# Altium schematic sheet main.SchDoc
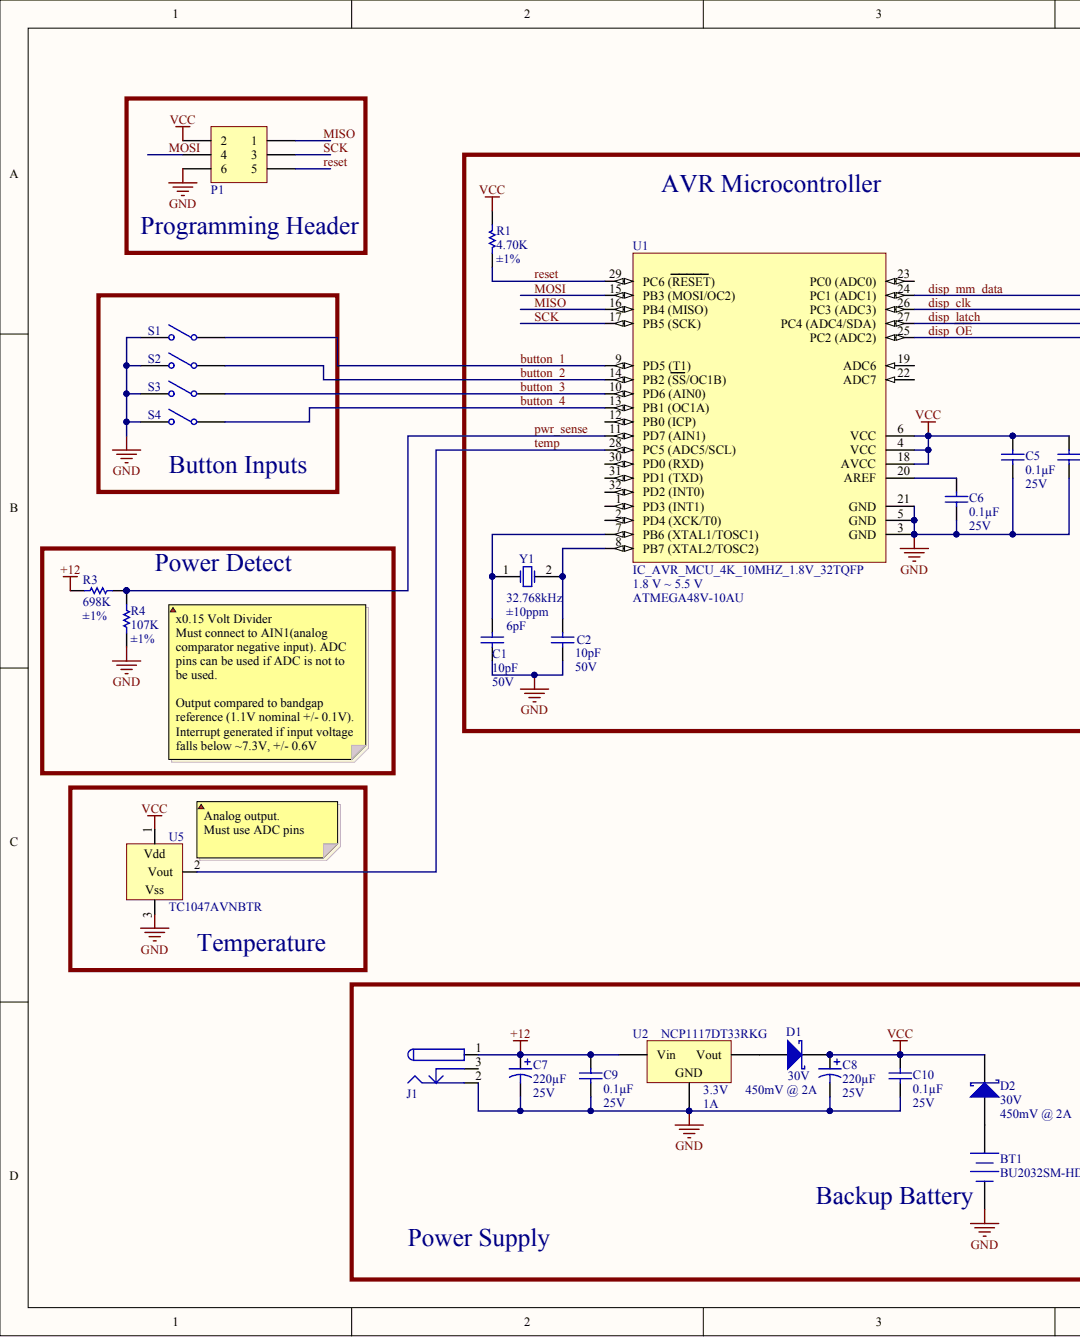
[diagram p0: main.SchDoc, left]
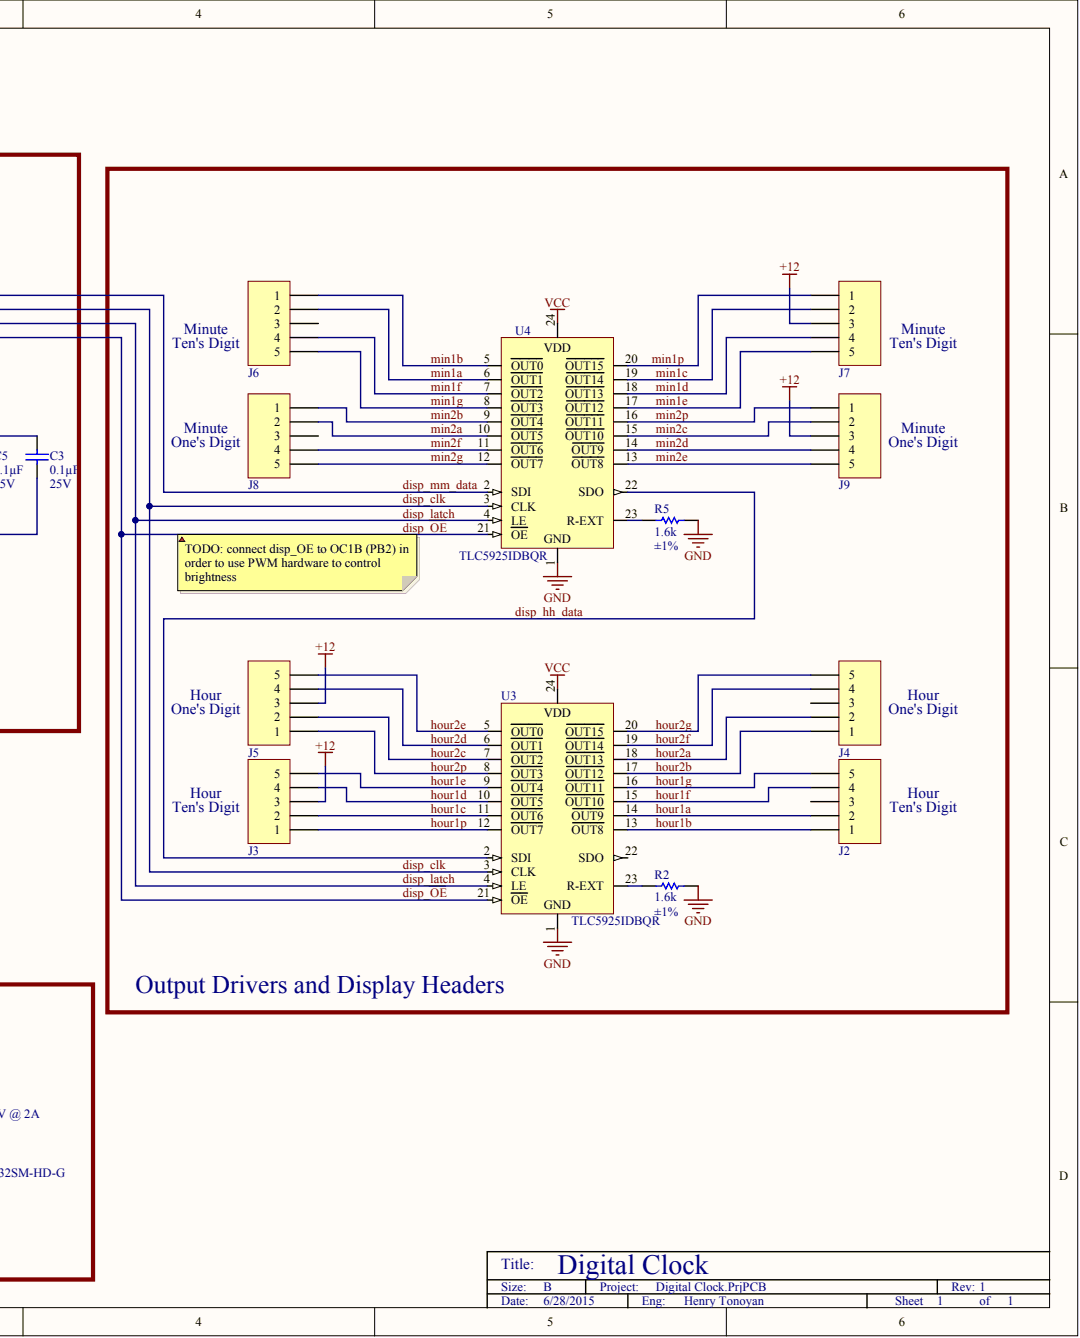
[diagram p1: main.SchDoc, right]

## Parts
BT1  HOLDER COIN CELL 2032 SMD  [BU2032SM-HD-G]  (value not drawn)
C1  Capacitance: 10pF  Voltage - Rated: 50V  [0603]  (hidden comment: CAP CER 10PF 50V 5% C0G 0603)
C2  Capacitance: 10pF  Voltage - Rated: 50V  [0603]  (hidden comment: CAP CER 10PF 50V 5% C0G 0603)
C3  Capacitance: 0.1µF  Voltage - Rated: 25V  [0603]  (hidden comment: CAP CER .10UF 25V X7R 10% 0603)
C5  Capacitance: 0.1µF  Voltage - Rated: 25V  [0603]  (hidden comment: CAP CER .10UF 25V X7R 10% 0603)
C6  Capacitance: 0.1µF  Voltage - Rated: 25V  [0603]  (hidden comment: CAP CER .10UF 25V X7R 10% 0603)
C7  Capacitance: 220µF  Voltage Rating: 25V  [CAP AVE227M25F24T-F]  (hidden comment: CAP ALUM 220UF 25V 20% SMD)
C8  Capacitance: 220µF  Voltage Rating: 25V  [CAP AVE227M25F24T-F]  (hidden comment: CAP ALUM 220UF 25V 20% SMD)
C9  Capacitance: 0.1µF  Voltage - Rated: 25V  [0603]  (hidden comment: CAP CER .10UF 25V X7R 10% 0603)
C10  Capacitance: 0.1µF  Voltage - Rated: 25V  [0603]  (hidden comment: CAP CER .10UF 25V X7R 10% 0603)
D1  Voltage - DC Reverse (Vr) (Max): 30V  [DSO-C2/X2.3]  (hidden comment: DIODE_SCHOTTKY_30V_2A_SMB)
D2  Voltage - DC Reverse (Vr) (Max): 30V  [DSO-C2/X2.3]  (hidden comment: DIODE_SCHOTTKY_30V_2A_SMB)
J1  CONN_PWR2.0_SMT  [CONN_PWR2.0_SMT]  (value not drawn)
J2  CONN_HEADER_VERT_5POS_.100_TIN  [CONN_HDR1X5]  (value not drawn)
J3  CONN_HEADER_VERT_5POS_.100_TIN  [CONN_HDR1X5]  (value not drawn)
J4  CONN_HEADER_VERT_5POS_.100_TIN  [CONN_HDR1X5]  (value not drawn)
J5  CONN_HEADER_VERT_5POS_.100_TIN  [CONN_HDR1X5]  (value not drawn)
J6  CONN_HEADER_VERT_5POS_.100_TIN  [CONN_HDR1X5]  (value not drawn)
J7  CONN_HEADER_VERT_5POS_.100_TIN  [CONN_HDR1X5]  (value not drawn)
J8  CONN_HEADER_VERT_5POS_.100_TIN  [CONN_HDR1X5]  (value not drawn)
J9  CONN_HEADER_VERT_5POS_.100_TIN  [CONN_HDR1X5]  (value not drawn)
P1  Header, 3-Pin, Dual row  [CONN_HDR_SMT_6_2R]  (value not drawn)
R1  Resistance (Ohms): 4.70K  Tolerance: ±1%  [0603]  (hidden comment: RES_4.70K_OHM_1/10W_1%_0603_SMD)
R2  Resistance (Ohms): 1.6k  Tolerance: ±1%  [0603]  (hidden comment: RES_1.60K_OHM_1/10W_1%_0603_SMD)
R3  Resistance (Ohms): 698K  Tolerance: ±1%  [0603]  (hidden comment: RES_698K_OHM_1/10W_1%_0603_SMD)
R4  Resistance (Ohms): 107K  Tolerance: ±1%  [0603]  (hidden comment: RES_107K_OHM_1/10W_1%_0603_SMD)
R5  Resistance (Ohms): 1.6k  Tolerance: ±1%  [0603]  (hidden comment: RES_1.60K_OHM_1/10W_1%_0603_SMD)
S1  SW_1571634-2  [1571634-2]  (value not drawn)
S2  SW_1571634-2  [1571634-2]  (value not drawn)
S3  SW_1571634-2  [1571634-2]  (value not drawn)
S4  SW_1571634-2  [1571634-2]  (value not drawn)
U1  IC_AVR_MCU_4K_10MHZ_1.8V_32TQFP  Manufacturer Part Number: ATMEGA48V-10AU  Voltage - Supply (Vcc/Vdd): 1.8 V ~ 5.5 V  [32A_N]
U2  Current - Limit (Min): 1A  Manufacturer Part Number: NCP1117DT33RKG  Voltage - Output: 3.3V  [DPAK]  (hidden comment: IC_REG_LDO_3.3V_DPAK)
U3  Manufacturer Part Number: TLC5925IDBQR  [SOP24]  (hidden comment: IC_LED_DRIVER_LINEAR_24-QSOP)
U4  Manufacturer Part Number: TLC5925IDBQR  [SOP24]  (hidden comment: IC_LED_DRIVER_LINEAR_24-QSOP)
U5  Manufacturer Part Number: TC1047AVNBTR  [SOT23-3N]  (hidden comment: Temperature Sensor)
Y1  Frequency: 32.768kHz  [ABS25]  (hidden comment: CRYSTAL_32.768_KHZ_6.0 PF_SMD)

## Nets
+12: C7.1 C9.2 J1.1 J3.3 J5.3 J7.3 J9.3 R3.1 U2.1
GND: BT1.2 C1.1 C2.1 C3.2 C5.2 C6.2 C7.2 C8.2 C9.1 C10.1 J1.2 P1.6 R2.2 R4.1 R5.2 S1.2 S2.2 S3.2 S4.2 U1.3(GND) U1.5(GND) U1.21(GND) U2.2 U3.1 U4.1 U5.3
VCC: C3.1 C5.1 C8.1 C10.2 D1.2 D2.2 P1.2 R1.2 U1.4(VCC) U1.6(VCC) U1.18(AVCC) U3.24 U4.24 U5.1
BUTTON_1: S1.1 U1.9(PD5_(T1))
BUTTON_2: S2.1 U1.14(PB2_(SS/OC1B))
BUTTON_3: S3.1 U1.10(PD6_(AIN0))
BUTTON_4: S4.1 U1.13(PB1_(OC1A))
DISP_CLK: U1.26(PC3_(ADC3)) U3.3(CLK) U4.3(CLK)
DISP_HH_DATA: U3.2(SDI) U4.22(SDO)
DISP_LATCH: U1.27(PC4_(ADC4/SDA)) U3.4(LE) U4.4(LE)
DISP_MM_DATA: U1.24(PC1_(ADC1)) U4.2(SDI)
DISP_~{OE}: U1.25(PC2_(ADC2)) U3.21(OE) U4.21(OE)
HOUR1A: J2.2 U3.14(OUT9)
HOUR1B: J2.1 U3.13(OUT8)
HOUR1C: J3.2 U3.11(OUT6)
HOUR1D: J3.4 U3.10(OUT5)
HOUR1E: J3.5 U3.9(OUT4)
HOUR1F: J2.4 U3.15(OUT10)
HOUR1G: J2.5 U3.16(OUT11)
HOUR1P: J3.1 U3.12(OUT7)
HOUR2A: J4.2 U3.18(OUT13)
HOUR2B: J4.1 U3.17(OUT12)
HOUR2C: J5.2 U3.7(OUT2)
HOUR2D: J5.4 U3.6(OUT1)
HOUR2E: J5.5 U3.5(OUT0)
HOUR2F: J4.4 U3.19(OUT14)
HOUR2G: J4.5 U3.20(OUT15)
HOUR2P: J5.1 U3.8(OUT3)
MIN1A: J6.2 U4.6(OUT1)
MIN1B: J6.1 U4.5(OUT0)
MIN1C: J7.2 U4.19(OUT14)
MIN1D: J7.4 U4.18(OUT13)
MIN1E: J7.5 U4.17(OUT12)
MIN1F: J6.4 U4.7(OUT2)
MIN1G: J6.5 U4.8(OUT3)
MIN1P: J7.1 U4.20(OUT15)
MIN2A: J8.2 U4.10(OUT5)
MIN2B: J8.1 U4.9(OUT4)
MIN2C: J9.2 U4.15(OUT10)
MIN2D: J9.4 U4.14(OUT9)
MIN2E: J9.5 U4.13(OUT8)
MIN2F: J8.4 U4.11(OUT6)
MIN2G: J8.5 U4.12(OUT7)
MIN2P: J9.1 U4.16(OUT11)
MISO: P1.1 U1.16(PB4_(MISO))
MOSI: P1.4 U1.15(PB3_(MOSI/OC2))
PWR_SENSE: R3.2 R4.2 U1.11(PD7_(AIN1))
RESET: P1.5 R1.1 U1.29(PC6_(RESET))
SCK: P1.3 U1.17(PB5_(SCK))
TEMP: U1.28(PC5_(ADC5/SCL)) U5.2
NetBT1_1: BT1.1 D2.1
NetC1_2: C1.2 U1.7(PB6_(XTAL1/TOSC1)) Y1.1
NetC2_2: C2.2 U1.8(PB7_(XTAL2/TOSC2)) Y1.2
NetC6_1: C6.1 U1.20(AREF)
NetD1_1: D1.1 U2.3
NetR2_1: R2.1 U3.23(R-EXT)
NetR5_1: R5.1 U4.23(R-EXT)

Not connected: J1 3; J2 3; J4 3; J6 3; J8 3; U1 1(PD3_(INT1)) 2(PD4_(XCK/T0)) 12(PB0_(ICP)) 19(ADC6) 22(ADC7) 23(PC0_(ADC0)) 30(PD0_(RXD)) 31(PD1_(TXD)) 32(PD2_(INT0)); U3 22(SDO)

## Library data of the parts
BT1 = BU2032SM-HD-G: Manufacturer: MPD (Memory Protection Devices); Battery Cell Size: Coin, 20.0mm; Battery Series: 2032; Battery Type, Function: Coin Cell, Holder; Number of Cells: 1; Style: Holder (Open); Category: Battery Products; Component Kind: Standard; ComponentLink1Description: Datasheet; ComponentLink1URL: http://www.memoryprotectiondevices.com/datasheets/BU2032SM-HD-G-datasheet.pdf; Description: HOLDER COIN CELL 2032 SMD; Height Above Board: 0.208" (5.28mm); Library Name: parts.SchLib; Manufacturer Part Number: BU2032SM-HD-G; Mounting Type: PCB, Surface Mount; Operating Temperature: -40°C ~ 280°C; Pricing 1: 1=0.82, 10=0.755 (USD); RoHS: RoHS Compliant; Stock 1: 33207; Supplier 1: Digi-Key; Supplier Part Number 1: BU2032SM-HD-GDKR-ND; Termination Style: SMD (SMT) Tab
C1 C2 = CAP_CER_10PF_50V_5%_C0G_0603: Manufacturer: Murata Electronics North America; Manufacturer Part Number: GRM1885C1H100JA01D; Temperature Coefficient: C0G, NP0; Applications: General Purpose; Category: Capacitors; Component Kind: Standard; ComponentLink1Description: Datasheet; ComponentLink1URL: http://search.murata.co.jp/Ceramy/image/img/PDF/ENG/GRM1885C1H100JA01.pdf; Description: CAP CER 10PF 50V 5% C0G 0603; Features: -; Height - Seated (Max): -; Lead Spacing: -; Lead Style: -; Library Name: parts.SchLib; Mounting Type: Surface Mount, MLCC; Operating Temperature: -55°C ~ 125°C; Package / Case: 0603 (1608 Metric); Packaging: Cut Tape (CT); Pricing 1: 1=0.1, 10=0.041, 50=0.0222, 100=0.0187, 250=0.01532, 500=0.0131, 1000=0.0102 (USD); Ratings: -; RoHS: RoHS Compliant; Size / Dimension: 0.063" L x 0.031" W (1.60mm x 0.80mm); Stock 1: 81574; Supplier 1: Digi-Key; Supplier Part Number 1: 490-1403-1-ND; Thickness (Max): 0.035" (0.90mm); Tolerance: ±5%
C3 C5 C6 C9 C10 = CAP_CER_.10UF_25V_X7R_10%_0603_1: Manufacturer: TDK Corporation; Manufacturer Part Number: C1608X7R1E104K; Applications: General Purpose; Category: Capacitors; Component Kind: Standard; ComponentLink1Description: Datasheet; ComponentLink1URL: http://www.tdk.com/pdf/general_B11.pdf; Description: CAP CER .10UF 25V X7R 10% 0603; Features: -; Height - Seated (Max): -; Lead Spacing: -; Lead Style: -; Library Name: parts.SchLib; Mounting Type: Surface Mount, MLCC; Operating Temperature: -55°C ~ 125°C; Package / Case: 0603 (1608 Metric); Packaging: Cut Tape (CT); Pricing 1: 1=0.1, 10=0.024, 100=0.011, 250=0.009, 500=0.0077, 1000=0.006 (USD); Ratings: -; RoHS: RoHS Compliant; Size / Dimension: 0.063" L x 0.031" W (1.60mm x 0.80mm); Stock 1: 1271235; Supplier 1: Digi-Key; Supplier Part Number 1: 445-1316-1-ND; Temperature Coefficient: X7R; Thickness (Max): 0.037" (0.95mm); Tolerance: ±10%
C7 C8 = CAP_ALUM_220UF_25V_20%_SMD: Manufacturer: Cornell Dubilier Electronics (CDE); Manufacturer Part Number: AVE227M25F24T-F; ESR (Equivalent Series Resistance): 1.06 Ohm; Lifetime @ Temp.: 2000 Hrs @ 85°C; Ripple Current: 252mA; Surface Mount Land Size: 0.331" L x 0.331" W (8.40mm x 8.40mm); Category: Capacitors; Component Kind: Standard; ComponentLink1Description: Datasheet; ComponentLink1URL: http://www.cde.com/catalogs/AVE.pdf; Description: CAP ALUM 220UF 25V 20% SMD; Features: General Purpose; Height - Seated (Max): 0.394" (10.00mm); Impedance: -; Lead Spacing: -; Library Name: parts.SchLib; Mounting Type: Surface Mount; Operating Temperature: -40°C ~ 85°C; Package / Case: Radial, Can - SMD; Packaging: Cut Tape (CT); Pricing 1: 1=0.69, 10=0.663, 100=0.3218, 250=0.26732 (USD); RoHS: RoHS Compliant; Size / Dimension: 0.315" Dia (8.00mm); Stock 1: 1214; Supplier 1: Digi-Key; Supplier Part Number 1: 338-1807-1-ND; Tolerance: ±20%
D1 D2 = DIODE_SCHOTTKY_30V_2A_SMB: DIODE SCHOTTKY 30V 2A SMB; Manufacturer: STMicroelectronics; Manufacturer Part Number: STPS2L30UF; Current - Reverse Leakage @ Vr: 200µA @ 30V; Speed: Fast Recovery =< 500ns, > 200mA (Io); Voltage - Forward (Vf) (Max) @ If: 450mV @ 2A; Capacitance @ Vr, F: -; Category: Discrete Semiconductor Products; Component Kind: Standard; ComponentLink1Description: Datasheet; ComponentLink1URL: http://www.st.com/internet/com/TECHNICAL_RESOURCES/TECHNICAL_LITERATURE/DATASHEET/CD00001633.pdf; Current - Average Rectified (Io): 2A; Diode Type: Schottky; Library Name: parts.SchLib; Mounting Type: Surface Mount; Package / Case: DO-214AA, SMB; Packaging: Digi-Reel®; Pricing 1: 1=0.91, 10=0.798, 25=0.7048, 100=0.6144, 250=0.53484, 500=0.45526, 1000=0.36465, 2500=0.32929 (USD); Reverse Recovery Time (trr): -; RoHS: RoHS Compliant; Stock 1: 6877; Supplier 1: Digi-Key; Supplier Device Package: SMBflat; Supplier Part Number 1: 497-8030-6-ND
J1 = CONN_PWR2.0_SMT: CONN PWR JACK 2.0X6.3MM SMT; Manufacturer: CUI Inc; Manufacturer Part Number: PJ-036A-SMT; Current Rating: 2.5A; Gender: Male; Internal Switch(s): Single Switch, Normally Closed; Number of Positions/Contacts: 2 Conductors, 3 Contacts; Plug/Mating Plug Diameter: 2.1mm ID, 5.5mm OD; Shielding: Unshielded; Voltage - Rated: 16VDC; Category: Connectors, Interconnects; Color: Black; Component Kind: Standard; ComponentLink1Description: Datasheet; ComponentLink1URL: http://www.cui.com/Product/Resource/DigiKeyPDF/PJ-036A-SMT.pdf; Connector Type: Jack; Contact Material: Brass; Contact Material - Plating: Silver; Features: -; Housing Color: Black; Housing Material: Thermoplastic; Library Name: parts.SchLib; Mounting Type: Surface Mount, Right Angle; Operating Temperature: -25°C ~ 85°C; Pricing 1: 1=1.67, 10=1.297, 100=0.9895 (USD); RoHS: RoHS Compliant; Stock 1: 20300; Supplier 1: Digi-Key; Supplier Part Number 1: CP-036APJCT-ND; Termination: Solder
J2-J9 = CONN_HEADER_VERT_5POS_.100_TIN: CONN HEADER VERT 5POS .100 TIN; Manufacturer: TE Connectivity; Manufacturer Part Number: 640454-5; Connector Type: Header, Unshrouded; Number of Positions: 5; Number of Positions Loaded: All; Pitch: 0.100" (2.54mm); Category: Connectors, Interconnects; Color: Natural; Component Kind: Standard; ComponentLink1Description: http://www.te.com/commerce/DocumentDelivery/DDEController?Action=srchrtrv&DocNm=640454&DocType=Customer+Drawing&DocLang=English; ComponentLink1URL: http://www.te.com/commerce/DocumentDelivery/DDEController?Action=srchrtrv&DocNm=640454&DocType=Customer+Drawing&DocLang=English; Contact Finish: Tin; Contact Finish Thickness: -; Contact Mating Length: 0.295" (7.49mm); Contact Type: Male Pin; Fastening Type: -; Features: Polarizing Key; Library Name: parts.SchLib; Mounting Type: Through Hole; Number of Rows: 1; Packaging: Bulk; Pricing 1: 1=0.19, 10=0.179, 50=0.1332, 100=0.1238, 250=0.10948, 500=0.10472, 1000=0.08568, 2500=0.07854, 5000=0.0714 (USD); RoHS: RoHS Compliant; Row Spacing: -; Stock 1: 9451; Supplier 1: Digi-Key; Supplier Part Number 1: A19432-ND; Termination: Solder
P1 = CONN_Header_0.100_6pos: Manufacturer: Molex Connector Corporation; Connector Type: Header, Unshrouded, Breakaway; Number of Positions: 6; Number of Positions Loaded: All; Pitch: 0.100" (2.54mm); Category: Connectors, Interconnects; Color: Black; Component Kind: Standard; ComponentLink1Description: Datasheet; ComponentLink1URL: http://www.molex.com/pdm_docs/sd/015912060_sd.pdf; Contact Finish: Tin; Contact Finish Thickness: 150µin (3.81µm); Contact Mating Length: 0.240" (6.10mm); Contact Type: Male Pin; Description: Header, 3-Pin, Dual row; Fastening Type: -; Features: -; Library Name: parts.SchLib; Manufacturer Part Number: 15-91-2060; Mounting Type: Surface Mount; Number of Rows: 2; Packaging: Bulk; Pricing 1: 1=0.82, 10=0.576, 50=0.4442, 100=0.3866, 250=0.329, 500=0.31256, 1000=0.27965, 2500=0.22208, 5000=0.21879 (USD); RoHS: RoHS Compliant; Row Spacing: 0.100" (2.54mm); Stock 1: 2541; Supplier 1: Digi-Key; Supplier Part Number 1: WM17449-ND; Termination: Solder
R1 = RES_4.70K_OHM_1/10W_1%_0603_SMD: RES 4.70K OHM 1/10W 1% 0603 SMD; Manufacturer: Panasonic Electronic Components; Manufacturer Part Number: ERJ-3EKF4701V; Power (Watts): 0.1W, 1/10W; Temperature Coefficient: ±100ppm/°C; Category: Resistors; Component Kind: Standard; ComponentLink1Description: Datasheet; ComponentLink1URL: http://industrial.panasonic.com/www-cgi/jvcr13pz.cgi?E+PZ+3+AOA0002+ERJ3EKF4701V+7+WW; Composition: Thick Film; Features: -; Height: 0.022" (0.55mm); Library Name: parts.SchLib; Number of Terminations: 2; Package / Case: 0603 (1608 Metric); Packaging: Cut Tape (CT); Pricing 1: 1=0.1, 50=0.0138, 100=0.0105, 250=0.0078, 500=0.00576, 1000=0.00384 (USD); RoHS: RoHS Compliant; Size / Dimension: 0.063" L x 0.031" W (1.60mm x 0.80mm); Stock 1: 955812; Supplier 1: Digi-Key; Supplier Part Number 1: P4.70KHCT-ND
R2 R5 = RES_1.60K_OHM_1/10W_1%_0603_SMD: RES 1.60K OHM 1/10W 1% 0603 SMD; Manufacturer: Panasonic Electronic Components; Manufacturer Part Number: ERJ-3EKF1601V; Power (Watts): 0.1W, 1/10W; Temperature Coefficient: ±100ppm/°C; Category: Resistors; Component Kind: Standard; ComponentLink1Description: Datasheet; ComponentLink1URL: http://industrial.panasonic.com/www-cgi/jvcr13pz.cgi?E+PZ+3+AOA0002+ERJ3EKF1601V+7+WW; Composition: Thick Film; Features: -; Height: 0.022" (0.55mm); Library Name: parts.SchLib; Number of Terminations: 2; Package / Case: 0603 (1608 Metric); Packaging: Digi-Reel®; Pricing 1: 1=0.1, 50=0.0138, 100=0.0105, 250=0.0078, 500=0.00576, 1000=0.00384 (USD); RoHS: RoHS Compliant; Size / Dimension: 0.063" L x 0.031" W (1.60mm x 0.80mm); Stock 1: 71684; Supplier 1: Digi-Key; Supplier Part Number 1: P1.60KHDKR-ND
R3 = RES_698K_OHM_1/10W_1%_0603_SMD: RES 698K OHM 1/10W 1% 0603 SMD; Manufacturer: Panasonic Electronic Components; Manufacturer Part Number: ERJ-3EKF6983V; Power (Watts): 0.1W, 1/10W; Temperature Coefficient: ±100ppm/°C; Category: Resistors; Component Kind: Standard; ComponentLink1Description: Datasheet; ComponentLink1URL: http://industrial.panasonic.com/www-cgi/jvcr13pz.cgi?E+PZ+3+AOA0002+ERJ3EKF6983V+7+WW; Composition: Thick Film; Features: -; Height: 0.022" (0.55mm); Library Name: parts.SchLib; Number of Terminations: 2; Package / Case: 0603 (1608 Metric); Packaging: Digi-Reel®; Pricing 1: 1=0.1, 50=0.0138, 100=0.0105, 250=0.0078, 500=0.00576, 1000=0.00384 (USD); RoHS: RoHS Compliant; Size / Dimension: 0.063" L x 0.031" W (1.60mm x 0.80mm); Stock 1: 28989; Supplier 1: Digi-Key; Supplier Part Number 1: P698KHDKR-ND
R4 = RES_107K_OHM_1/10W_1%_0603_SMD: RES 107K OHM 1/10W 1% 0603 SMD; Manufacturer: Panasonic Electronic Components; Manufacturer Part Number: ERJ-3EKF1073V; Power (Watts): 0.1W, 1/10W; Temperature Coefficient: ±100ppm/°C; Category: Resistors; Component Kind: Standard; ComponentLink1Description: Datasheet; ComponentLink1URL: http://industrial.panasonic.com/www-cgi/jvcr13pz.cgi?E+PZ+3+AOA0002+ERJ3EKF6983V+7+WW; Composition: Thick Film; Features: -; Height: 0.022" (0.55mm); Library Name: parts.SchLib; Number of Terminations: 2; Package / Case: 0603 (1608 Metric); Packaging: Cut Tape (CT); Pricing 1: 1=0.1, 50=0.0138, 100=0.0105, 250=0.0078, 500=0.00576, 1000=0.00384 (USD); RoHS: RoHS Compliant; Size / Dimension: 0.063" L x 0.031" W (1.60mm x 0.80mm); Stock 1: 116149; Supplier 1: Digi-Key; Supplier Part Number 1: P107KHCT-ND
S1-S4 = SW_1571634-2: Single-Pole, Single-Throw Switch; Manufacturer: TE Connectivity / Alcoswitch; Contact Form: SPST-NO; Current Rating: 50 mA; Illumination: Not Illuminated; Switch Function: Momentary; Voltage Rating DC: 24 V; Category: Pushbutton Switches; Component Kind: Standard; ComponentLink1Description: Datasheet; ComponentLink1URL: http://www.mouser.com/ds/2/418/NG_CD_1571634_F1-116640.pdf; LatestRevisionDate: 17-Jul-2002; LatestRevisionNote: Re-released for DXP Platform.; Library Name: parts.SchLib; Manufacturer Part Number: 1571634-2; Minimum Order Quantity: 1; Mounting Style: SMD/SMT; PackageDescription: Switch; 2 Leads; PackageReference: SPST-2; Packaging: Reel; Pricing 1: 1=0.392, 25=0.347, 50=0.316, 100=0.302, 200=0.271, 500=0.240, 1000=0.227 (AUD); Product Category: Pushbutton Switches; Published: 24-Mar-1999; Reeling: True; RoHS: RoHS Compliant; RoHS - Mouser: Y; Standard Pack Qty: 1000; Stock 1: 711; Supplier 1: Mouser; Supplier Part Number 1: 506-1571634-2; Termination Style: Gull Wing; Publisher: Altium Limited
U1 = IC_AVR_MCU_4K_10MHZ_1.8V_32TQFP: IC AVR MCU 4K 10MHZ 1.8V 32TQFP; Manufacturer: Atmel; Connectivity: I²C, SPI, UART/USART; Core Size: 8-Bit; Data Converters: A/D 8x10b; EEPROM Size: 256 x 8; Number of I /O: 23; Oscillator Type: Internal; Peripherals: Brown-out Detect/Reset, POR, PWM, WDT; Program Memory Size: 4KB (2K x 16); Program Memory Type: FLASH; RAM Size: 512 x 8; Category: Integrated Circuits (ICs); Component Kind: Standard; ComponentLink1Description: Datasheet; ComponentLink1URL: http://www.atmel.com/Images/2545s.pdf; Core Processor: AVR; Library Name: parts.SchLib; Operating Temperature: -40°C ~ 85°C; Package / Case: 32-TQFP; Packaging: Tray; Pricing 1: 1=2.73, 25=1.7144, 100=1.524 (USD); RoHS: RoHS Compliant; Speed: 10MHz; Stock 1: 15971; Supplier 1: Digi-Key; Supplier Part Number 1: ATMEGA48V-10AU-ND
U2 = IC_REG_LDO_3.3V_DPAK: IC REG LDO 3.3V DPAK; Manufacturer: ON Semiconductor; Number of Regulators: 1; Regulator Topology: Positive Fixed; Voltage - Dropout (Typical): 1.07V @ 800mA; Voltage - Input: Up to 20V; Category: Integrated Circuits (ICs); Component Kind: Standard; ComponentLink1Description: Datasheet; ComponentLink1URL: http://www.onsemi.com/pub_link/Collateral/NCP1117-D.PDF; Current - Output: 1A; Library Name: parts.SchLib; Mounting Type: Surface Mount; Operating Temperature: 0°C ~ 125°C; Package / Case: TO-252-3, DPak (2 Leads + Tab), SC-63; Packaging: Cut Tape (CT); Pricing 1: 1=0.49, 10=0.417, 25=0.3652, 100=0.3127, 250=0.2712, 500=0.22972, 1000=0.1771 (USD); RoHS: RoHS Compliant; Stock 1: 9630; Supplier 1: Digi-Key; Supplier Device Package: DPAK-3; Supplier Part Number 1: NCP1117DT33RKGOSCT-ND
U3 U4 = IC_LED_DRIVER_LINEAR_24-QSOP: IC LED DRIVER LINEAR 24-QSOP; Manufacturer: Texas Instruments; Constant Current: Yes; Frequency: 30MHz; Number of Outputs: 16; Topology: Linear, High Side; Type - Primary: LED Lighting Display, Signage, Automotive; Voltage - Output: 17V; Voltage - Supply: 3 V ~ 5.5 V; Category: Integrated Circuits (ICs); Component Kind: Standard; ComponentLink1Description: Datasheet; ComponentLink1URL: http://www.ti.com/lit/ds/symlink/tlc5925.pdf; Constant Voltage: -; Internal Driver: Yes; Library Name: parts.SchLib; Mounting Type: Surface Mount; Operating Temperature: -40°C ~ 85°C; Package / Case: 24-SSOP (0.154", 3.90mm Width); Packaging: Cut Tape (CT); Pricing 1: 1=1.6, 10=1.44, 25=1.29, 100=1.16, 250=1.03, 500=0.9, 1000=0.745 (USD); RoHS: RoHS Compliant; Stock 1: 6953; Supplier 1: Digi-Key; Supplier Device Package: 24-SSOP/QSOP; Supplier Part Number 1: 296-23916-1-ND; Type - Secondary: -
U5 = IC_TEMP-VOLT_CONV_PREC_SOT-23B: IC TEMP-VOLT CONV PREC SOT-23B; Manufacturer: Microchip Technology; Accuracy: ±0.5°C; Output Type: Voltage; Sensing Temperature: -40°C ~ 125°C; Voltage - Supply: 2.5 V ~ 5.5 V; Category: Sensors, Transducers; Component Kind: Standard; ComponentLink1Description: Datasheet; ComponentLink1URL: http://ww1.microchip.com/downloads/en/DeviceDoc/21498c.pdf; Library Name: parts.SchLib; Package / Case: TO-236-3, SC-59, SOT-23-3; Packaging: Cut Tape (CT); Pricing 1: 1=0.74, 10=0.62, 25=0.52, 100=0.47 (USD); RoHS: RoHS Compliant; Stock 1: 17104; Supplier 1: Digi-Key; Supplier Device Package: SOT-23-3; Supplier Part Number 1: TC1047AVNBCT-ND
Y1 = CRYSTAL_32.768_KHZ_6.0 PF_SMD: CRYSTAL 32.768 KHZ 6.0 PF SMD; Manufacturer: Abracon Corporation; Manufacturer Part Number: ABS25-32.768KHZ-6-1-T; ESR (Equivalent Series Resistance): 50 kOhm; Frequency Tolerance: ±10ppm; Load Capacitance: 6pF; Operating Mode: Fundamental; Category: Crystals and Oscillators; Component Kind: Standard; ComponentLink1Description: Datasheet; ComponentLink1URL: http://www.abracon.com/Resonators/abs25.pdf; Frequency Stability: -; Height: 0.098" (2.50mm); Library Name: parts.SchLib; Mounting Type: Surface Mount; Operating Temperature: -40°C ~ 85°C; Package / Case: 4-SOJ, 5.50mm pitch; Packaging: Cut Tape (CT); Pricing 1: 1=1.01, 10=0.878, 50=0.7696, 100=0.6075, 500=0.513 (USD); Ratings: -; RoHS: RoHS Compliant; Size / Dimension: 0.315" L x 0.150" W (8.00mm x 3.80mm); Stock 1: 11234; Supplier 1: Digi-Key; Supplier Part Number 1: 535-10241-1-ND; Type: kHz Crystal (Tuning Fork)
